# Altium schematic sheet MCU.SchDoc
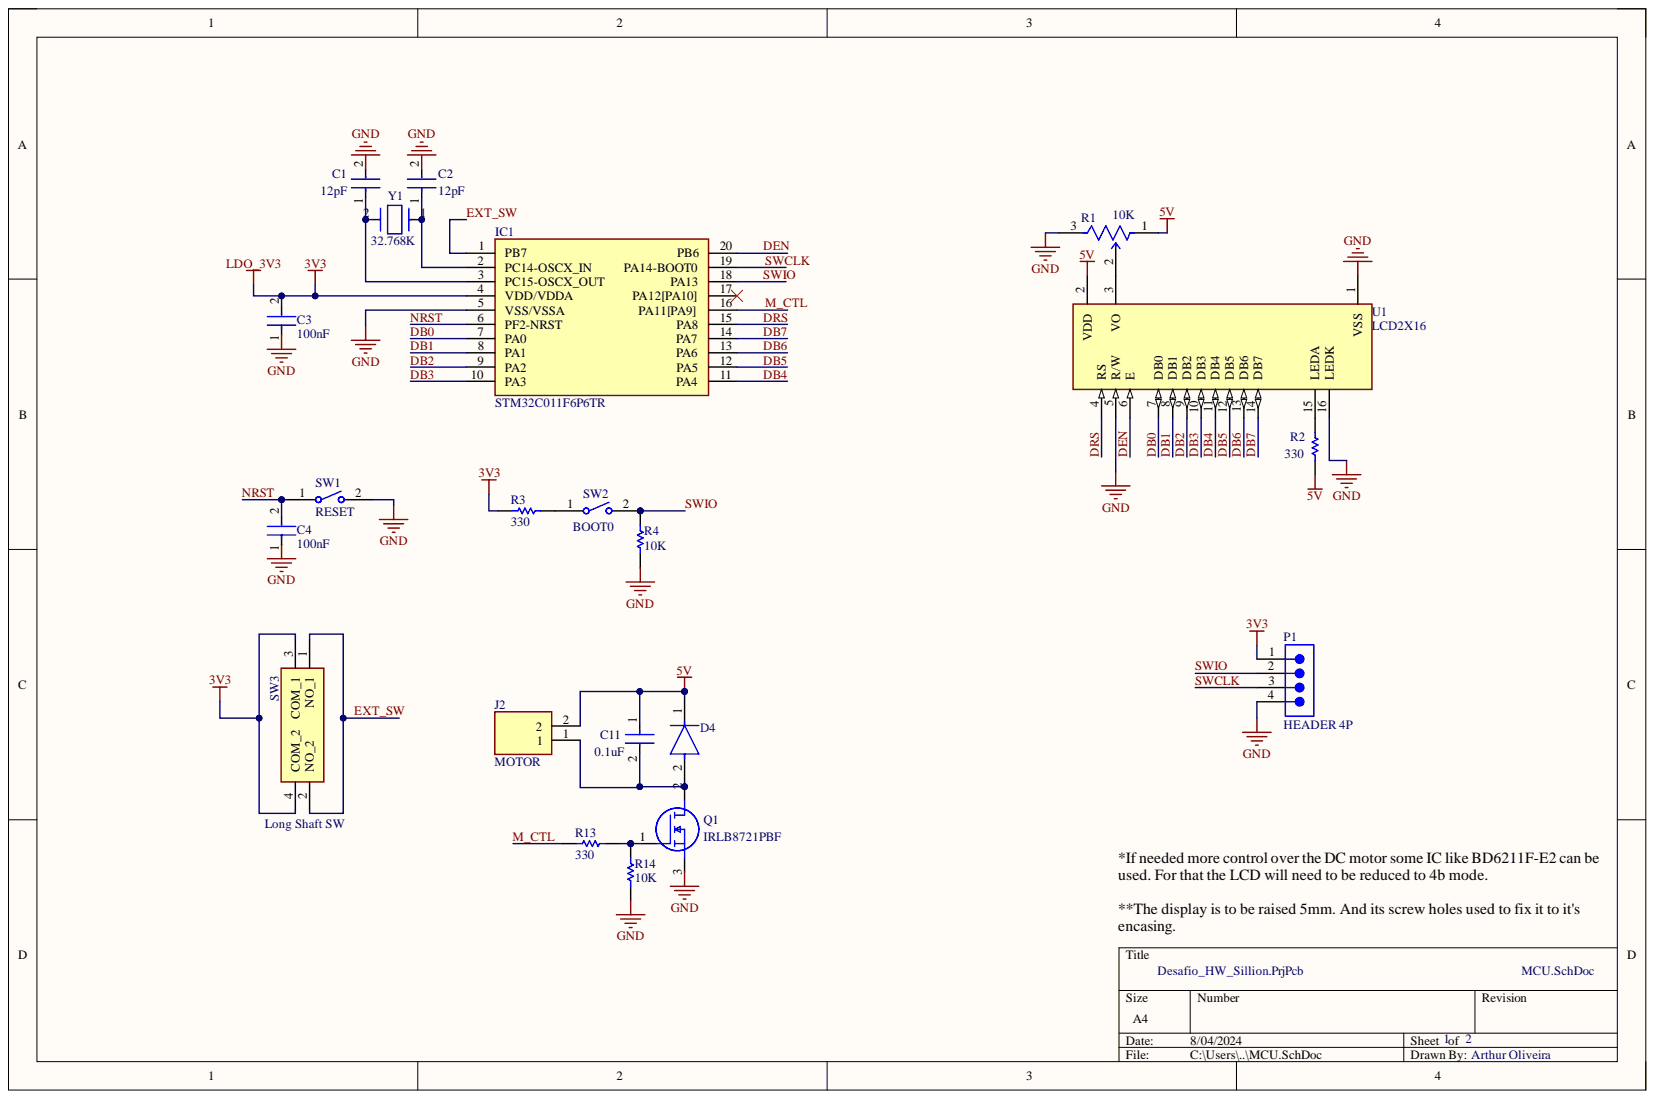
[diagram p0: MCU.SchDoc]

## Parts
C1  12pF  [FP-C0603C-CF-MFG]
C2  12pF  [FP-C0603C-CF-MFG]
C3  100nF  [FP-CC0805-0_85-MFG]
C4  100nF  [FP-CC0805-0_85-MFG]
C11  0.1uF  [FP-C0603-CJ-MFG]
D4  SBRT5A50SA-13  [FP-SMA-MFG]  (value not drawn)
IC1  STM32C011F6P6TR  [SOP65P640X120-20N]
J2  MOTOR  [1715022]
P1  HEADER 4P  [61300411121]
Q1  IRLB8721PBF  [TO254P483X1016X1994-3P]
R1  10K  [FP-3314J-1-MFG]
R2  330  [RESC2013X60X40LL20T25]
R3  330  [RESC2013X60X40LL20T25]
R4  10K  [RESC2013X60X40LL20T25_A]
R13  330  [RESC2013X60X40LL20T25]
R14  10K  [RESC2013X60X40LL20T25_A]
SW1  RESET  [TECO-FSMSM-2_V]
SW2  BOOT0  [TECO-FSMSM-2_V]
SW3  Long Shaft SW  [TS0266130BK160LCRD]
U1  LCD2X16  [LCD_LCD2X16]
Y1  32.768K  [FP-NX3215SA-MFG]

## Nets
3V3: C3.2 IC1.4(VDD/VDDA) P1.1 R3.2 SW3.3(COM_1) SW3.4(COM_2)
5V: C11.1 D4.1 J2.2 R1.1 R2.2 U1.2(VDD)
GND: C1.2 C2.2 C3.1 C4.1 IC1.5(VSS/VSSA) P1.4 Q1.3 R1.3 R4.1 R14.1 SW1.2 U1.1(VSS) U1.5(R/W) U1.16(LEDK)
DB0: IC1.7(PA0) U1.7(DB0)
DB1: IC1.8(PA1) U1.8(DB1)
DB2: IC1.9(PA2) U1.9(DB2)
DB3: IC1.10(PA3) U1.10(DB3)
DB4: IC1.11(PA4) U1.11(DB4)
DB5: IC1.12(PA5) U1.12(DB5)
DB6: IC1.13(PA6) U1.13(DB6)
DB7: IC1.14(PA7) U1.14(DB7)
DEN: IC1.20(PB6) U1.6(E)
DRS: IC1.15(PA8) U1.4(RS)
EXT_SW: IC1.1(PB7) SW3.1(NO_1) SW3.2(NO_2)
M_CTL: IC1.16(PA11[PA9]) R13.2
NRST: C4.2 IC1.6(PF2-NRST) SW1.1
SWCLK: IC1.19(PA14-BOOT0) P1.3
SWIO: IC1.18(PA13) P1.2 R4.2 SW2.2
NetC1_1: C1.1 IC1.3(PC15-OSCX_OUT) Y1.2
NetC2_1: C2.1 IC1.2(PC14-OSCX_IN) Y1.1
NetC11_2: C11.2 D4.2 J2.1 Q1.2
NetQ1_1: Q1.1 R13.1 R14.2
NetR1_2: R1.2 U1.3(VO)
NetR2_1: R2.1 U1.15(LEDA)
NetR3_1: R3.1 SW2.1

Not connected: IC1 17(PA12[PA10])

## Library data of the parts
C1 C2 = CMP-2006-03703-3: Ceramic Capacitor 12Pf 50V, C0G, 5%, 0603, Full Reel; Manufacturer: KEMET; Manufacturer Part Number: C0603C120J5GACTU; Automotive Grade: Grade 1; Temperature Coefficient: 30ppm/°C; Tolerance: 0.05; Automotive: Yes; Capacitance: 12 pF; Category: Cap; Component Kind: Standard; ComponentLink2Description: Datasheet; ComponentLink2URL: https://api.kemet.com/component-edge/download/datasheet/C0603C100J5GACAUTO.pdf; Device Class L1: Passive Components; Device Class L2: Capacitors; Device Class L3: Ceramic Capacitors; Digikey Description: Ceramic Capacitor 12Pf 50V, C0G, 5%, 0603, Full Reel; Height: 0.87mm; Lead Free: Yes; Library Name: Altium Content Vault; Material: Ceramic; Mouser Description: Ceramic Capacitor 12Pf 50V, C0G, 5%, 0603, Full Reel; Octopart: https://octopart.com/c0603c120j5gactu-kemet-108780; Package: C0603C-CF; RoHS: TRUE; Temperature: -55°C to +125°C; Temperature Characteristic: C0G; Temperature Range High: +125°C; Temperature Range Low: -55°C; Value: 12 pF; Voltage Rating: 50 V
C3 C4 = CMP-1036-04402-6: CAP CER 0.1UF 16V X7R 0805; Manufacturer: Yageo; Manufacturer Part Number: CC0805KRX7R7BB104; Material: Ceramic; Tolerance: 0.1; Automotive: No; Capacitance: 100 nF; Category: Cap; Component Kind: Standard; ComponentLink2Description: Datasheet; ComponentLink2URL: http://www.yageo.com/documents/recent/UPY-GPHC_Y5V_6.3V-to-50V_8.pdf; Device Class L1: Passive Components; Device Class L2: Capacitors; Device Class L3: Ceramic Capacitors; Digikey Description: CAP CER 0.1UF 16V X7R 0805; Footprint Url: http://www.yageo.com/exep/pages/download/literatures/UPY-C_GEN_24.pdf; Height: 0.95mm; Ipc Land Pattern Name: CAPC200125X85; Lead Free: Yes; Library Name: Altium Content Vault; Mouser Description: Multilayer Ceramic Capacitors MLCC - SMD/SMT 100nF 16V X7R 10%; Octopart: https://octopart.com/cc0805krx7r7bb104-yageo-39467769; Package: CC0805-0.85; RoHS: TRUE; Temperature: -30°C to +85°C; Temperature Characteristic: X7R; Temperature Range High: +85°C; Temperature Range Low: -30°C; Value: 100 nF; Voltage Rating: 16 V
C11 = CMP-2006-03300-2: CAP CER 0.1UF 50V X7R 0603; Manufacturer: Kemet; Manufacturer Part Number: C0603X104K5RACTU; Capacitance: 100nF; Material: Ceramic; Temperature Coefficient: 15%; Tolerance: 10%; Value: 100nF; Automotive: No; Category: Cap; Component Kind: Standard; ComponentLink2Description: Datasheet; ComponentLink2URL: https://content.kemet.com/datasheets/KEM_C1013_X7R_FT-CAP_SMD.pdf; Device Class L1: Passive Components; Device Class L2: Capacitors; Device Class L3: Ceramic Capacitors; Digikey Description: CAP CER 0.1UF 50V X7R 0603; Height: 0.95mm; Ipc Land Pattern Name: CAPC16080X80; Lead Free: Yes; Library Name: Altium Content Vault; Mouser Description: Capacitor; Ceramic; Cap 0.1uF; Tol+10%; SMT; Flexible Termination; Vol-Rtg 50V; TR; Octopart: https://octopart.com/c0603x104k5ractu-kemet-9145062; Package: C0603-CJ; RoHS: TRUE; Temperature: -55°C to +125°C; Temperature Characteristic: X7R; Temperature Range High: +125°C; Temperature Range Low: -55°C; Voltage: 50V; Voltage Rating: 50V
D4 = CMP-12791-000002-1: DIODE SBR 50V 5A SMA; Manufacturer: Diodes Inc.; Max Junction Temp: +150°C; Max Surge Forward Current: 70A; Peak Reverse Current: 30uA; Standoff Height: 0.05mm; Automotive: No; Category: Diode; Component Kind: Standard; ComponentLink2Description: Datasheet; ComponentLink2URL: https://www.diodes.com/assets/Datasheets/SBRT5A50SA.pdf; Device Class L1: Discrete Semiconductors; Device Class L2: Diodes; Device Class L3: Rectifier Diodes; Digikey Description: DIODE SBR 50V 5A SMA; Footprint Url: https://www.diodes.com/assets/Package-Files/SMA.pdf; Forward Current: 5A; Height: 2.4mm; Lead Free: Yes; Library Name: Altium Content Vault; Manufacturer Part Number: SBRT5A50SA-13; Max Repetitive Reverse Voltage: 50V; Mouser Description: DIODE SBR 50V 5A SMA; Octopart: https://octopart.com/sbrt5a50sa-13-diodes+inc.-46962091; Package: SMA; RoHS: TRUE; Temperature: -55°C to +150°C; Temperature Range High: +150°C; Temperature Range Low: -55°C
IC1 = STM32C011F6P6TR: Integrated Circuit; Manufacturer_Name: STMicroelectronics; Arrow Part Number: STM32C011F6P6TR; Arrow Price/Stock: null?region=nac; Component Kind: Standard; Datasheet Link: https://www.st.com/resource/en/datasheet/stm32c011d6.pdf; Height: 1.2mm; Manufacturer_Part_Number: STM32C011F6P6TR; Mouser Part Number: 511-STM32C011F6P6TR; Mouser Price/Stock: https://www.mouser.co.uk/ProductDetail/STMicroelectronics/STM32C011F6P6TR?qs=rQFj71Wb1eUlrPzOdA5ImQ%3D%3D
J2 = 1715022: Connector; Manufacturer_Name: Phoenix Contact; Arrow Part Number: 1715022; Arrow Price/Stock: null?region=nac; Component Kind: Standard; Datasheet Link: http://www.phoenixcontact.com/de/produkte/1715022/pdf; Height: 13.85mm; Manufacturer_Part_Number: 1715022; Mouser Part Number: 651-1715022; Mouser Price/Stock: https://www.mouser.co.uk/ProductDetail/Phoenix-Contact/1715022?qs=A%2FKWGUWTCZi6QclA%252B6CJ3A%3D%3D
P1 = CMP-1502-01064-3: NexarPartId: 32855522; Connector Type: Male Pin; Contact Resistance: 20mΩ; Current Rating: 3A; Gender: Male; Number of Contacts: 4; Operating Supply Voltage: 250V; Pitch: 2.54mm; Color: Black; Component Kind: Standard; Contact Material: Copper; Contact Mating Length: 5.9944mm; Contact Plating: Gold; Height: 8.89mm; Lead Free: Lead Free; Lead Pitch: 2.54mm; Library Name: Altium Content Vault; Max Operating Temperature: 105°C; Min Operating Temperature: -40°C; Mount: Through Hole; Number of Pins: 4; Number of Positions: 4; Number of Rows: 1; Orientation: Vertical; Packaging: Bulk; REACH SVHC: Unknown; RoHS: Compliant; Termination: Solder; Voltage Rating: 250V
Q1 = IRLB8721PBF: MOSFET (N-Channel); Manufacturer_Name: Infineon; Arrow Part Number: IRLB8721PBF; Arrow Price/Stock: https://www.arrow.com/en/products/irlb8721pbf/infineon-technologies-ag?region=europe; Component Kind: Standard; Datasheet Link: http://uk.rs-online.com/web/p/products/7259322; Height: 4.83mm; Manufacturer_Part_Number: IRLB8721PBF; Mouser Part Number: 942-IRLB8721PBF; Mouser Price/Stock: https://www.mouser.co.uk/ProductDetail/Infineon-Technologies/IRLB8721PBF?qs=9%252BKlkBgLFf0T58WYx%2FAl5A%3D%3D
R1 = CMP-07234-000035-1: TRIMMER 10K OHM 0.25W J LEAD TOP; Component Kind: Standard; Library Name: Altium Content Vault; RoHS Compliant: Yes
R2 R3 R13 = CMP-2001-00628-1: Manufacturer: Panasonic; Part Number: ERA6AEB331V; Component Kind: Standard; Library Name: Altium Content Vault
R4 R14 = CMP-2001-00582-2: Metal Film Chip Resistor, High Reliability Type, 0.125 W, +/- 0.1%, -55 to 155 degC, 0805 (2012 Metric), RoHS, Tape and Reel; Brand: Panasonic; Power Rating: 125mW(1/8W); Resistance: 10kOhms; Temperature Coefficient: 25PPM/C; Voltage Rating: 100V; Case Code - in: 0805; Case Code - mm: 2012; Component Kind: Standard; Datasheet URL: https://industrial.panasonic.com/cdbs/www-data/pdf/RDM0000/AOA0000C307.pdf; Datasheet Version: 02/2016; Factory Pack Quantity: 5000; Height: 0.5mm; Length: 2mm; Library Name: Altium Content Vault; Manufacturer: Panasonic; Manufacturer URL: http://www.panasonic.com/; Mounting Technology: SurfaceMount; Operating Temperature Range: -55Cto+155C; Package / Case: 0805(2012metric); Package Description: 2-Pin Surface Mount Device, Body 2 x 1.25 mm; Package Reference: 0805; Packaging: Reel; Product: ThinFilmResistorsSMD; Product Category: ThinFilmResistors-SMD; RoHS: Details; Series: ERA-6A; Termination Style: SMD/SMT; Tolerance: 0.1%; Type: MetalFilmChipResistors; Width: 1.25mm
SW1 SW2 = CMP-2000-07511-1: FSMSM Push Button Switch, 50 mA, -35 to 85 degC, 2-Pin SMD, RoHS, Bulk; Manufacturer: TE Connectivity; Actuator Length: 1.07mm; Actuator Material: Polyamide; Case\/Package: SMD/SMT; Contact Current Rating: 50mA; Contact Resistance: 100mOhm; Electrical Life: 20000Cycles; Illumination Type: Illuminated; Max Voltage Rating (DC): 12V; Operating Force: 180gf; Switch Travel: 0.25mm; Throw Configuration: SPST; Voltage Rating (DC): 24V; Component Kind: Standard; Contact Material: Brass; Contact Plating: Silver; Current Rating: 50mA; Datasheet URL: http://www.te.com/commerce/DocumentDelivery/DDEController?Action=showdoc&DocId=Customer+Drawing%7F1437566-3%7FC2%7Fpdf%7FEnglish%7FENG_CD_1437566-3_C2_1437566_3_1_c.dwg.pdf%7F1437566-3; Datasheet Version: Rev. C2, 08/2014; ELV: Compliant; Lead Free: LeadFree; Library Name: Altium Content Vault; Manufacturer URL: http://www.te.com/; Max Operating Temperature: 85degC; Mechanical Life: 20000Cycles; Min Operating Temperature: -35degC; Mount: SurfaceMount; Mounting Technology: SurfaceMount; Orientation: Straight; Package Description: 2-Pin Surface Mount Device, Body 6 x 3.5 mm, Pitch 9.19 mm; Package Quantity: 1000; Package Reference: FSMSM; Packaging: LoosePiece; REACH SVHC: Unknown; RoHSCompliant: true; Termination: GullWing
SW3 = TS02-66-130-BK-160-LCR-D: Integrated Circuit; Manufacturer_Name: CUI Devices; Component Kind: Standard; Datasheet Link: https://www.cuidevices.com/product/resource/ts02.pdf; Height: 13.2mm; Manufacturer_Part_Number: TS02-66-130-BK-160-LCR-D; Mouser Part Number: 179-TS0266130BK160LC; Mouser Price/Stock: https://www.mouser.co.uk/ProductDetail/CUI-Devices/TS02-66-130-BK-160-LCR-D?qs=A6eO%252BMLsxmRpI4OEfaqDHA%3D%3D
U1 = LCD2X16: Display; Display: LCD 2x16 characters (blue backlight); Availability: Unavailable; Component Kind: Standard; Library Name: LCD2X16.IntLib; MP: LCD2X16; Package: None; Price: None
Y1 = CMP-200220-000010-1: CRYSTAL 32.7680KHZ 12.5PF SMD; ESR (Equivalent Series Resistance): 70kR; Frequency: 32.768kHz; Frequency Tolerance: 20ppm; Case/Package: SMD/SMT; Component Kind: Standard; Height: 0.035inch; Length: 0.126inch; Library Name: Altium Content Vault; Load Capacitance: 12.5pF; Max Operating Temperature: 125°C; Min Operating Temperature: -40°C; Mount: Surface Mount; Number of Pins: 2; Package Quantity: 1000; Packaging: Tape and Reel; Width: 0.059inch
